# Altium schematic sheet UI_CCU_HAS.SchDoc
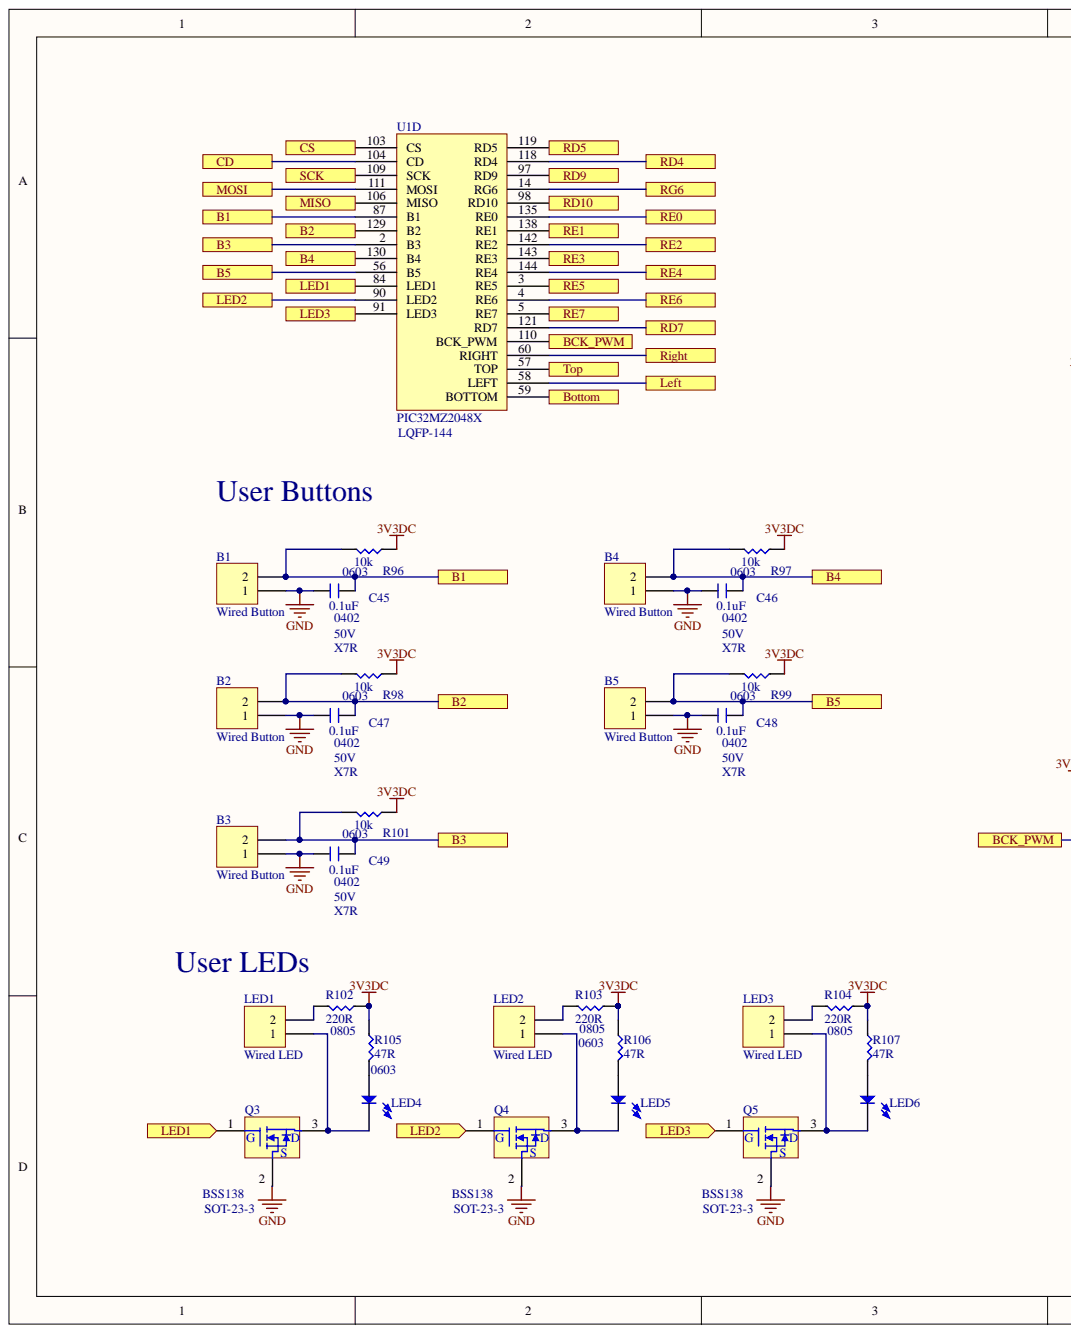
[diagram p0: UI_CCU_HAS.SchDoc, left]
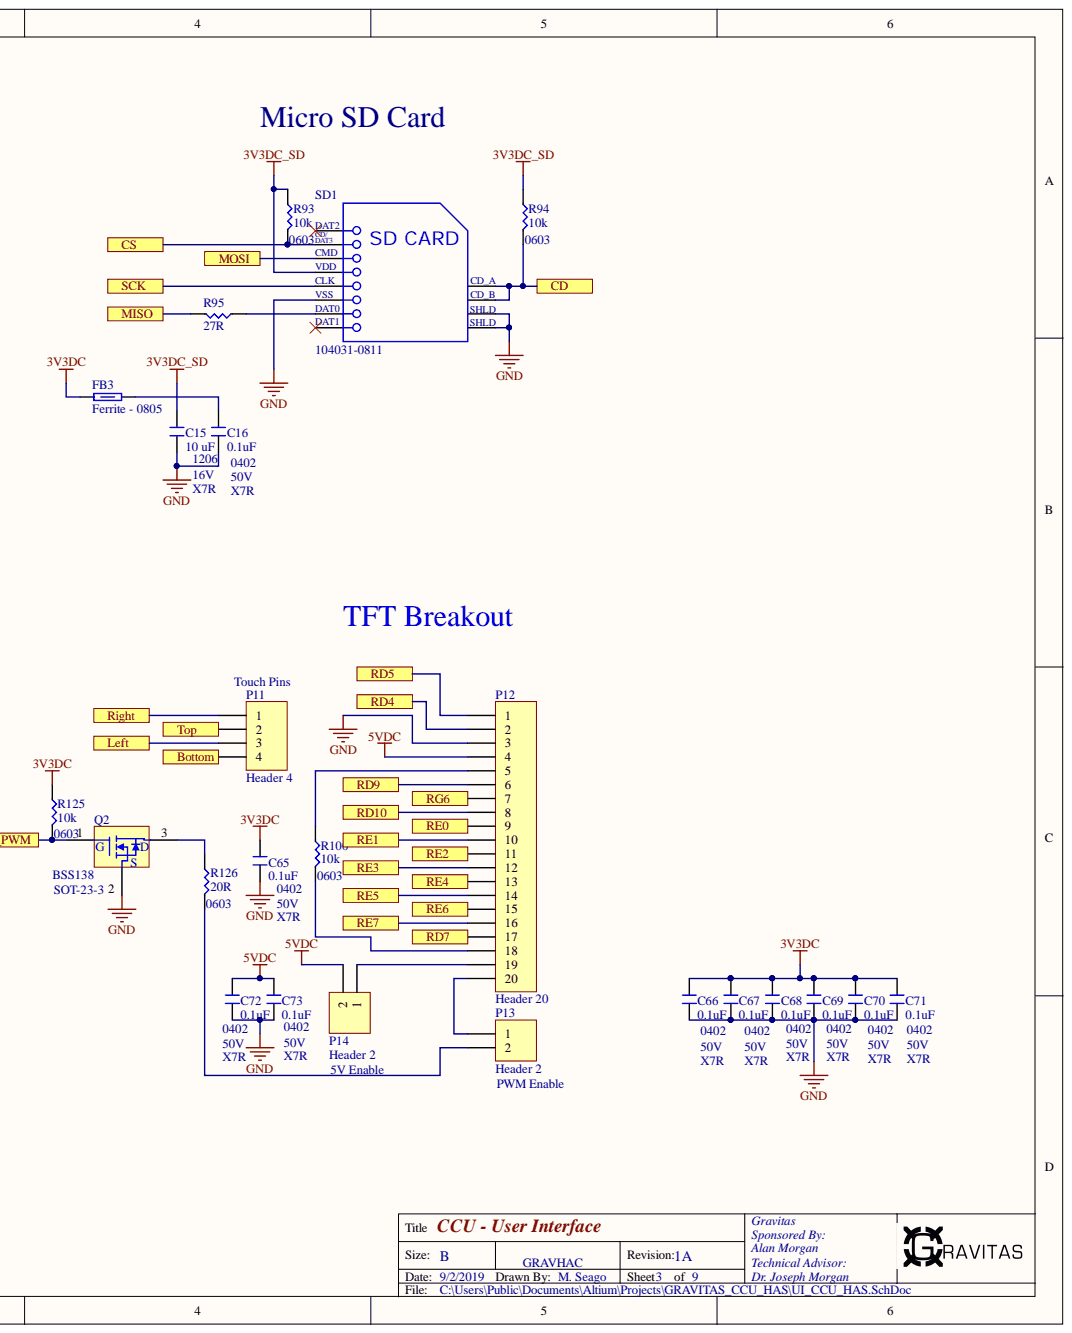
[diagram p1: UI_CCU_HAS.SchDoc, right]

## Parts
B1  Wired Button  [HDR1X2]
B2  Wired Button  [HDR1X2]
B3  Wired Button  [HDR1X2]
B4  Wired Button  [HDR1X2]
B5  Wired Button  [HDR1X2]
C15  10 uF  [NONPOLAR-1206]
C16  0.1uF  [NonPolar-0402]
C45  0.1uF  [NonPolar-0402]
C46  0.1uF  [NonPolar-0402]
C47  0.1uF  [NonPolar-0402]
C48  0.1uF  [NonPolar-0402]
C49  0.1uF  [NonPolar-0402]
C65  0.1uF  [NonPolar-0402]
C66  0.1uF  [NonPolar-0402]
C67  0.1uF  [NonPolar-0402]
C68  0.1uF  [NonPolar-0402]
C69  0.1uF  [NonPolar-0402]
C70  0.1uF  [NonPolar-0402]
C71  0.1uF  [NonPolar-0402]
C72  0.1uF  [NonPolar-0402]
C73  0.1uF  [NonPolar-0402]
FB3  Ferrite - 0805  [NonPolar-0805]
LED1  Wired LED  [HDR1X2]
LED2  Wired LED  [HDR1X2]
LED3  Wired LED  [HDR1X2]
LED4  LED-0603  [LED-0603]  (value not drawn)
LED5  LED-0603  [LED-0603]  (value not drawn)
LED6  LED-0603  [LED-0603]  (value not drawn)
P11  Header 4  [HDR1X4]
P12  Header 20  [HDR1X20]
P13  Header 2  [HDR1X2]
P14  Header 2  [HDR1X2]
Q2  BSS138  [BSS138-SOT23]
Q3  BSS138  [BSS138-SOT23]
Q4  BSS138  [BSS138-SOT23]
Q5  BSS138  [BSS138-SOT23]
R93  10k  [NonPolar-0603]
R94  10k  [NonPolar-0603]
R95  27R  [NonPolar-0603]
R96  10k  [NonPolar-0603]
R97  10k  [NonPolar-0603]
R98  10k  [NonPolar-0603]
R99  10k  [NonPolar-0603]
R100  10k  [NonPolar-0603]
R101  10k  [NonPolar-0603]
R102  220R  [NonPolar-0805]
R103  220R  [NonPolar-0805]
R104  220R  [NonPolar-0805]
R105  47R  [NonPolar-0603]
R106  47R  [NonPolar-0603]
R107  47R  [NonPolar-0603]
R125  10k  [NonPolar-0603]
R126  20R  [NonPolar-0603]
SD1  104031-0811  [MOLX-104031-0811_V]
U1  PIC32MZ2048X  [PIC32MZ-FlatPack-144 Pin]  (value not drawn)  (part D)

## Ports
B1, B2, B3, B4, B5, BCK_PWM, Bottom, CD, CS, LED1, LED2, LED3, Left, MISO, MOSI, RD4, RD5, RD7, RD9, RD10, RE0, RE1, RE2, RE3, RE4, RE5, RE6, RE7, RG6, Right, SCK, Top

## Nets
3V3DC: C65.2 C66.2 C67.2 C68.2 C69.2 C70.2 C71.2 FB3.1 R96.2 R97.2 R98.2 R99.2 R101.2 R102.2 R103.2 R104.2 R105.2 R106.2 R107.2 R125.2
3V3DC_SD: C15.2 R93.2 R94.2 SD1.4
5VDC: C72.2 C73.2 P12.4 P14.2
GND: B1.1 B2.1 B3.1 B4.1 B5.1 C15.1 C16.1 C45.1 C46.1 C47.1 C48.1 C49.1 C65.1 C66.1 C67.1 C68.1 C69.1 C70.1 C71.1 C72.1 C73.1 P12.3 Q2.2 Q3.2 Q4.2 Q5.2 SD1.6 SD1.11 SD1.12
B1: B1.2 C45.2 R96.1 U1.87(B1) ; port B1
B2: B2.2 C47.2 R98.1 U1.129(B2) ; port B2
B3: B3.2 C49.2 R101.1 U1.2(B3) ; port B3
B4: B4.2 C46.2 R97.1 U1.130(B4) ; port B4
B5: B5.2 C48.2 R99.1 U1.56(B5) ; port B5
BCK_PWM: R125.1 U1.110(BCK_PWM) ; port BCK_PWM
Bottom: P11.4 U1.59(BOTTOM) ; port Bottom
CD: R94.1 SD1.9 SD1.10 U1.104(CD) ; port CD
CS: R93.1 SD1.2(SD_CARD) U1.103(CS) ; port CS
LED1: U1.84(LED1) ; port LED1
LED2: U1.90(LED2) ; port LED2
LED3: U1.91(LED3) ; port LED3
Left: P11.3 U1.58(LEFT) ; port Left
MISO: R95.1 U1.106(MISO) ; port MISO
MOSI: SD1.3 U1.111(MOSI) ; port MOSI
RD4: P12.2 U1.118(RD4) ; port RD4
RD5: P12.1 U1.119(RD5) ; port RD5
RD7: P12.17 U1.121(RD7) ; port RD7
RD9: P12.6 U1.97(RD9) ; port RD9
RD10: P12.8 U1.98(RD10) ; port RD10
RE0: P12.9 U1.135(RE0) ; port RE0
RE1: P12.10 U1.138(RE1) ; port RE1
RE2: P12.11 U1.142(RE2) ; port RE2
RE3: P12.12 U1.143(RE3) ; port RE3
RE4: P12.13 U1.144(RE4) ; port RE4
RE5: P12.14 U1.3(RE5) ; port RE5
RE6: P12.15 U1.4(RE6) ; port RE6
RE7: P12.16 U1.5(RE7) ; port RE7
RG6: P12.7 U1.14(RG6) ; port RG6
Right: P11.1 U1.60(RIGHT) ; port Right
SCK: SD1.5 U1.109(SCK) ; port SCK
Top: P11.2 U1.57(TOP) ; port Top
NetC16_2: C16.2 FB3.2
NetLED1_1: LED1.1 LED4.2 Q3.3
NetLED1_2: LED1.2 R102.1
NetLED2_1: LED2.1 LED5.2 Q4.3
NetLED2_2: LED2.2 R103.1
NetLED3_1: LED3.1 LED6.2 Q5.3
NetLED3_2: LED3.2 R104.1
NetLED4_1: LED4.1 R105.1
NetLED5_1: LED5.1 R106.1
NetLED6_1: LED6.1 R107.1
NetP12_5: P12.5 R100.2
NetP12_18: P12.18 R100.1
NetP12_19: P12.19 P14.1
NetP12_20: P12.20 P13.1
NetP13_2: P13.2 R126.1
NetQ2_3: Q2.3 R126.2
NetR95_2: R95.2 SD1.7

Not connected: Q2 1; Q3 1; Q4 1; Q5 1; SD1 1 8

## Library data of the parts
B1-B5 LED1-LED3 P13 P14 = Header 2: Header, 2-Pin; Component Kind: Standard; LatestRevisionDate: 17-Jul-2002; LatestRevisionNote: Re-released for DXP Platform.; Library Name: Miscellaneous Connectors.IntLib; Signal Integrity: Connector; Publisher: Altium Limited
C15 = Cap - 1206: CGA5L1X7R1E106K160AE; Component Kind: Standard; Library Name: GravLib.IntLib
C16 C45-C49 C65-C73 = Cap - 0402: Component Kind: Standard; Library Name: GravLib.IntLib
P11 = Header 4: Header, 4-Pin; Component Kind: Standard; LatestRevisionDate: 17-Jul-2002; LatestRevisionNote: Re-released for DXP Platform.; Library Name: Miscellaneous Connectors.IntLib; Signal Integrity: Connector; Publisher: Altium Limited
P12 = Header 20: Header, 20-Pin; Component Kind: Standard; LatestRevisionDate: 17-Jul-2002; LatestRevisionNote: Re-released for DXP Platform.; Library Name: Miscellaneous Connectors.IntLib; Signal Integrity: Connector; Publisher: Altium Limited
R93-R101 R105-R107 R125 R126 = Res - 0603: Component Kind: Standard; Library Name: GravLib.IntLib
R102-R104 = Res - 0805: Component Kind: Standard; Library Name: GravLib.IntLib
SD1 = CMP-2000-05825-1: Micro SD Card, RA, -25 to 85 degC, 8-Pin SMD, RoHS, Tape and Reel; Brand: Molex; Current Rating: 500mA; Insertion - Extraction Style: Push-Pull; Mating Cycles: 10000; Number of Contacts: 8; Pitch: 1.1mm; Voltage Rating: 10VAC/DC; Card Type: microSD; Component Kind: Standard; Contact Material: PhosphorBronze; Contact Plating: Gold; Datasheet URL: http://www.molex.com/pdm_docs/sd/1040310811_sd.pdf; Datasheet Version: Rev. E, 04/2006; Height: 1.42mm; Housing Material: LiquidCrystalPolymer(LCP); Length: 11.4mm; Library Name: Altium Content Vault; Manufacturer: Molex; Manufacturer URL: http://www.molex.com/; Mounting Technology: SurfaceMount; Mounting Type: Standard; Number of Rows: 1Row; Orientation: RightAngle; Package Description: 8-Pin Surface Mount Device, Body 11.95 x 11.4 mm, Pitch 1.1 mm; Package Reference: 104031-0811; Packaging: Reel; Product: CardConnectors; Product Category: MemoryCardConnectors; RoHS: True; Series: 104031; Termination Style: SMD
FB3 = Ferrite - 0805: Component Kind: Standard; Library Name: GravLib.IntLib
LED4-LED6 = LED-0603: Component Kind: Standard; Library Name: GravLib.IntLib
Q2-Q5 = BSS138: Component Kind: Standard; Library Name: GravLib.IntLib
U1 = PIC32MZ2048X: Component Kind: Standard; Library Name: GravLib.IntLib
